# Altium schematic sheet SENSOR.SchDoc
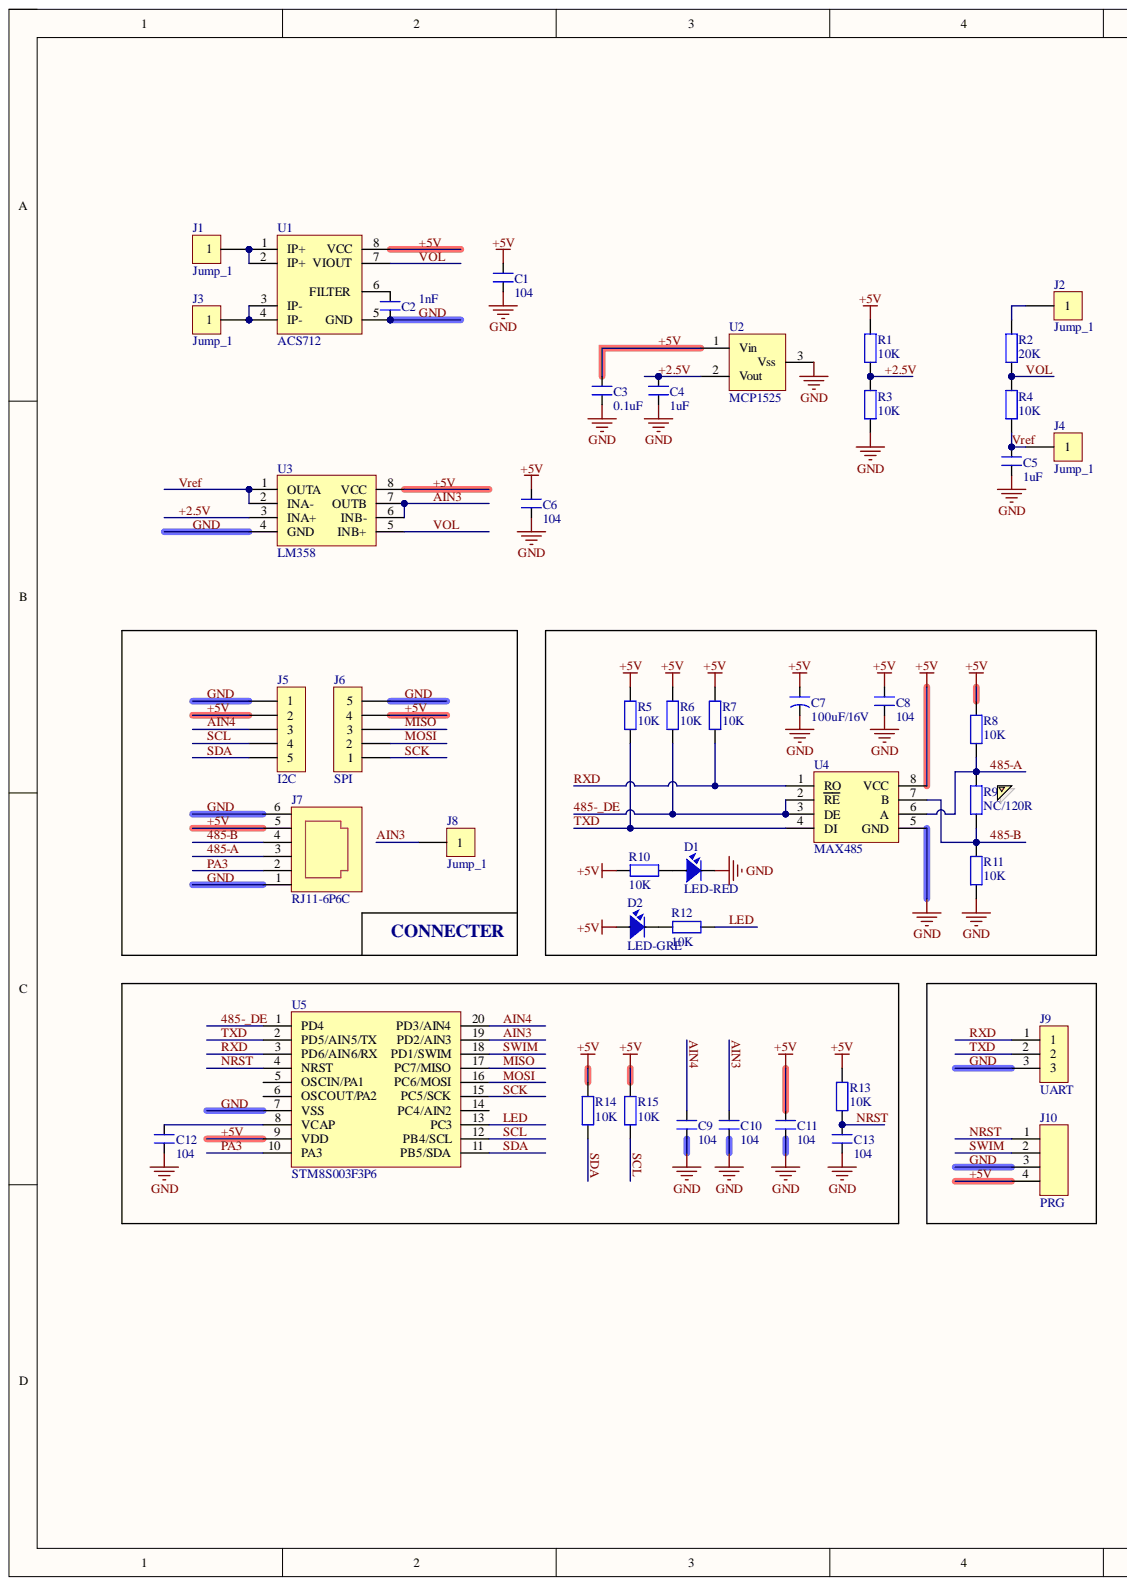
[diagram p0: SENSOR.SchDoc, left]
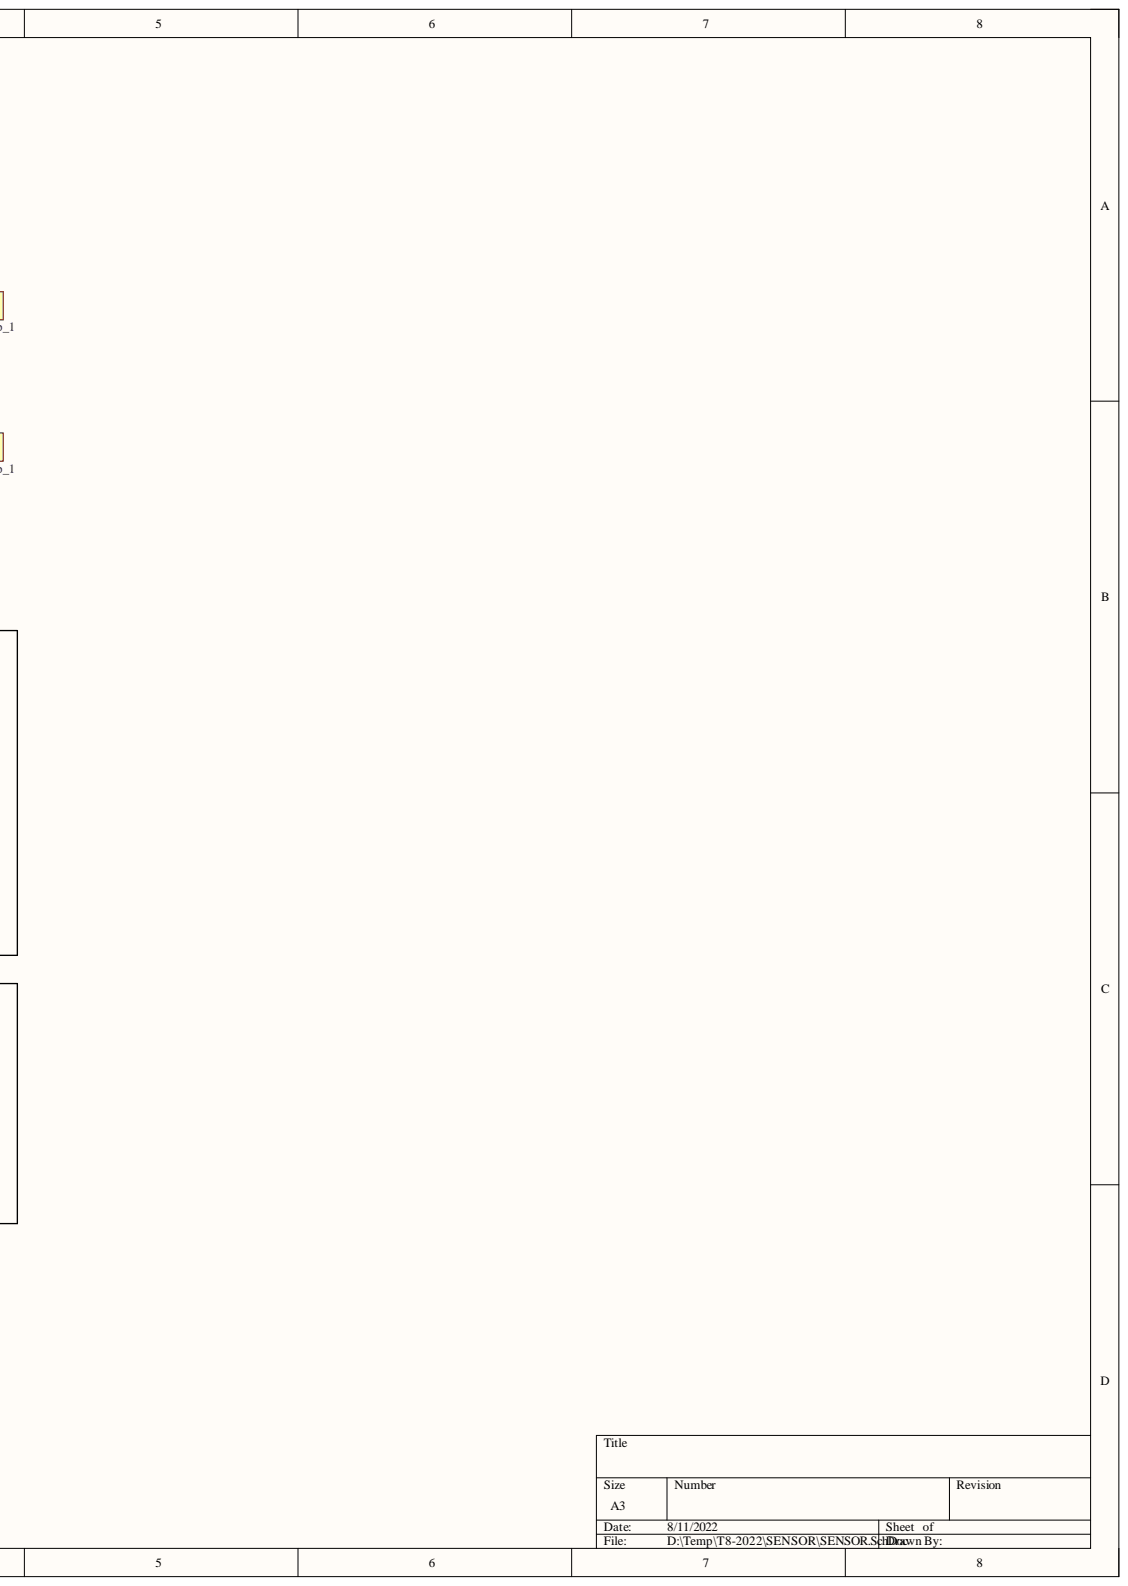
[diagram p1: SENSOR.SchDoc, right]

## Parts
C1  104  [CAP-0805]
C2  1nF  [CAP-0805]
C3  0.1uF  [CAP-0805]
C4  1uF  [CAP-0805]
C5  1uF  [CAP-0805]
C6  104  [CAP-0805]
C7  100uF/16V  [CAP_AL_6.3x5.5]
C8  104  [CAP-0805]
C9  104  [CAP-0805]
C10  104  [CAP-0805]
C11  104  [CAP-0805]
C12  104  [CAP-0805]
C13  104  [CAP-0805]
D1  LED-RED  [LED-3-R]
D2  LED-GRE  [LED-3-G]
J1  Jump_1  [JUMP_1]
J2  Jump_1  [JUMP_1]
J3  Jump_1  [JUMP_1]
J4  Jump_1  [JUMP_1]
J5  I2C  [CON2 15EDG3.81-5P]
J6  SPI  [CON2 15EDG3.81-5P]
J7  RJ11-6P6C  [RJ12-6P6C]
J8  Jump_1  [JUMP_1]
J9  UART  [JUMP_3]
J10  PRG  [Jump_4]
R1  10K  [RES-0805]
R2  20K  [RES-0805]
R3  10K  [RES-0805]
R4  10K  [RES-0805]
R5  10K  [RES-0805]
R6  10K  [RES-0805]
R7  10K  [RES-0805]
R8  10K  [RES-0805]
R9  NC/120R  [RES-0805]
R10  10K  [RES-0805]
R11  10K  [RES-0805]
R12  10K  [RES-0805]
R13  10K  [RES-0805]
R14  10K  [RES-0805]
R15  10K  [RES-0805]
U1  ACS712  [SOP08]
U2  MCP1525  [SOT_23 - 132]
U3  LM358  [SOIC-8]
U4  MAX485  [SOP08]
U5  STM8S003F3P6  [TSSOP20]

## Nets
485-_DE: R6.1 U4.2(RE) U4.3(DE) U5.1(PD4)
485-A: J7.3 R8.1 R9.2 U4.6(A)
485-B: J7.4 R9.1 R11.2 U4.7(B)
+2.5V: C4.2 R1.1 R3.2 U2.2 U3.3(INA+)
+5V: C1.2 C3.2 C6.2 C7.1 C8.2 C11.2 D2.1 J5.2 J6.4 J7.5 J10.4 R1.2 R5.2 R6.2 R7.2 R8.2 R10.2 R13.2 R14.2 R15.2 U1.8(VCC) U2.1 U3.8(VCC) U4.8(VCC) U5.9(VDD)
AIN3: C10.2 J8.1 U3.6(INB-) U3.7(OUTB) U5.19(PD2/AIN3)
AIN4: C9.2 J5.3 U5.20(PD3/AIN4)
GND: C1.1 C2.1 C3.1 C4.1 C5.1 C6.1 C7.2 C8.1 C9.1 C10.1 C11.1 C12.1 C13.1 D1.2 J5.1 J6.5 J7.1 J7.6 J9.3 J10.3 R3.1 R11.1 U1.5(GND) U2.3 U3.4(GND) U4.5(GND) U5.7(VSS)
LED: R12.1 U5.13(PC3)
MISO: J6.3 U5.17(PC7/MISO)
MOSI: J6.2 U5.16(PC6/MOSI)
NRST: C13.2 J10.1 R13.1 U5.4(NRST)
PA3: J7.2 U5.10(PA3)
RXD: J9.1 R7.1 U4.1(RO) U5.3(PD6/AIN6/RX)
SCK: J6.1 U5.15(PC5/SCK)
SCL: J5.4 R15.1 U5.12(PB4/SCL)
SDA: J5.5 R14.1 U5.11(PB5/SDA)
SWIM: J10.2 U5.18(PD1/SWIM)
TXD: J9.2 R5.1 U4.4(DI) U5.2(PD5/AIN5/TX)
VOL: R2.1 R4.2 U1.7(VIOUT) U3.5(INB+)
Vref: C5.2 J4.1 R4.1 U3.1(OUTA) U3.2(INA-)
NetC2_2: C2.2 U1.6(FILTER)
NetC12_2: C12.2 U5.8(VCAP)
NetD1_1: D1.1 R10.1
NetD2_2: D2.2 R12.2
NetJ1_1: J1.1 U1.1(IP+) U1.2(IP+)
NetJ2_1: J2.1 R2.2
NetJ3_1: J3.1 U1.3(IP-) U1.4(IP-)

Not connected: U5 5(OSCIN/PA1) 6(OSCOUT/PA2) 14(PC4/AIN2)

## Library data of the parts
C1-C6 C8-C13 = CAP: Cap SMD; Value: 103; Component Kind: Standard; Library Name: OAD_RD.IntLib
C7 = CAP_AL: Cap AL SMD; Component Kind: Standard; Library Name: OAD_RD.IntLib
D1 D2 = LED-DIP: Led; Component Kind: Standard; Library Name: OAD_RD.IntLib
J5 J6 = Jump_5: Jump_5 Pin 2.54; Component Kind: Standard; Library Name: OAD_RD.IntLib
J7 = RJ11-6P6C: RJ11-6P6C Connecter; Component Kind: Standard; Library Name: OAD_RD.IntLib
J9 = Jump_3: Jump_3 Pin 2.54; Component Kind: Standard; Library Name: OAD_RD.IntLib
J10 = Jump_4: Jump_4 Pin 2.54; Component Kind: Standard; Library Name: OAD_RD.IntLib
R1-R15 = RES: Resistor SMD; Value: 1K; Component Kind: Standard; Library Name: OAD_RD.IntLib
U1 = ACS712: Fully Integrated, Hall Effect-Based Linear Current Sensor with 2.1 kVRMS Voltage Isolation and a Low-Resistance Current Conductor; Component Kind: Standard; Library Name: OAD_RD.IntLib
U2 = MCP1525: Vref; Component Kind: Standard; Library Name: OAD_RD.IntLib
U3 = LM358: https://www.ti.com/lit/ds/symlink/lm158-n.pdf; Component Kind: Standard; Library Name: OAD_RD.IntLib
U4 = MAX485: RS485-Transceivers; Component Kind: Standard; Library Name: OAD_RD.IntLib
U5 = STM8S003F3P6: ARM; Component Kind: Standard; Library Name: OAD_RD.IntLib
J1-J4 J8 = Jump_1: Component Kind: Standard; Description: Jump_1; Library Name: OAD_RD.IntLib
